# Altium schematic sheet Load_Share_controller.SchDoc
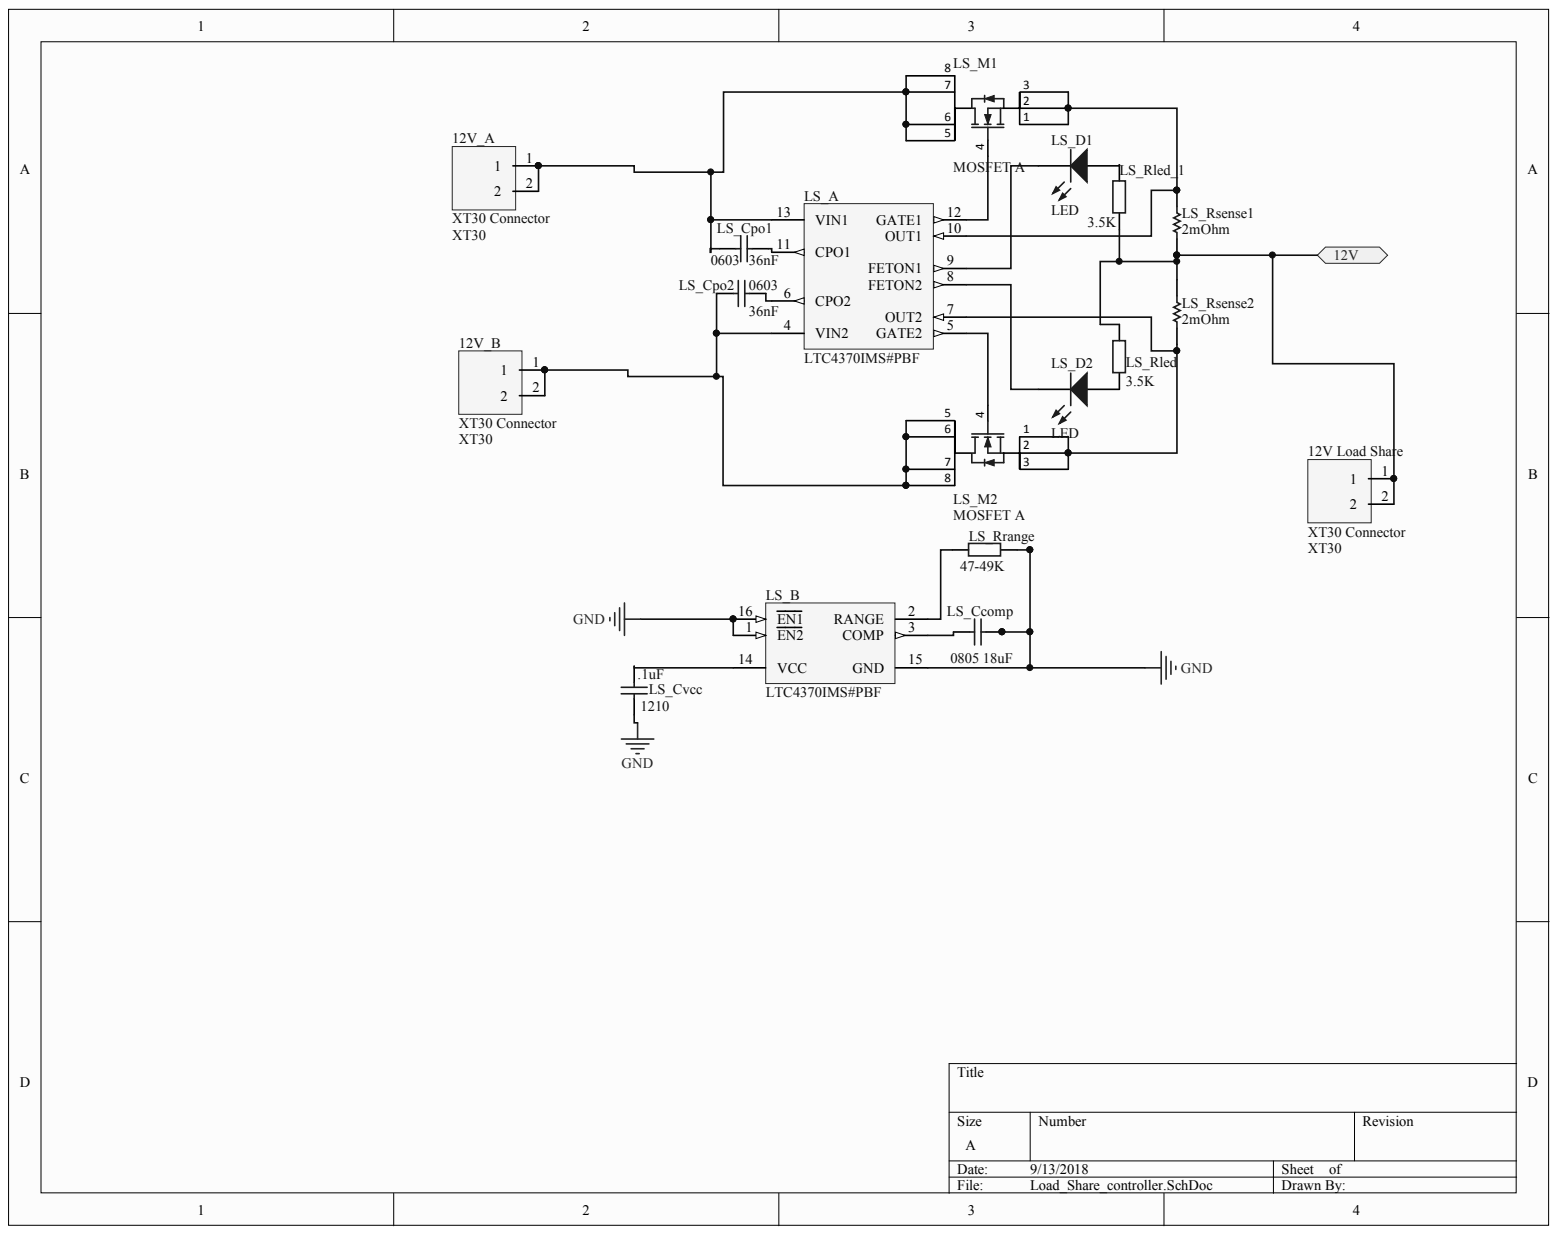
[diagram p0: Load_Share_controller.SchDoc]

## Parts
12V_Load_Share  XT30 Connector  Name: XT30  [PCB-k7b7qcz15wuei3yaobu8-1]
12V_A  XT30 Connector  Name: XT30  [PCB-k7b7qcz15wuei3yaobu8-1]
12V_B  XT30 Connector  Name: XT30  [PCB-k7b7qcz15wuei3yaobu8-1]
LS_  LTC4370IMS#PBF  [LT-MS-16_L]  (parts A,B)
LS_Ccomp  Capacitance: 18uF  Package: 0805  [CAPC2014X135X70LL20]  (hidden comment: AVX Interconnect / Elco TLCR107M004XTA)
LS_Cpo1  Capacitance: 36nF  Case/Package: 0603  [0603-CAP]  (hidden comment: Kemet C0603C561J5GACTU)
LS_Cpo2  Capacitance: 36nF  Case/Package: 0603  [0603-CAP]  (hidden comment: Kemet C0603C561J5GACTU)
LS_Cvcc  Capacitance: .1uF  Package: 1210  [PCB-xq3c4pfyq04n43f4i2bn-1]  (hidden comment: Taiyo Yuden HMK325B7225KN-T)
LS_D1  LED  [PCB-0nqcqa48p5nqkflv7v2c-1]
LS_D2  LED  [PCB-0nqcqa48p5nqkflv7v2c-1]
LS_M1  MOSFET A  [PCB-19722066-1]
LS_M2  MOSFET A  [PCB-19722066-1]
LS_Rled  Resistance: 3.5K  [PCB-xt88hm5qtlxo4k7muxaf-1]
LS_Rled_1  Resistance: 3.5K  [PCB-xt88hm5qtlxo4k7muxaf-1]
LS_Rrange  Resistance: 47-49K  [PCB-xt88hm5qtlxo4k7muxaf-1]
LS_Rsense1  2mOhm  [PCB-9l1y7q8wfh2j5q7sti4n-1]
LS_Rsense2  2mOhm  [PCB-9l1y7q8wfh2j5q7sti4n-1]

## Ports
12V

## Nets
GND: LS_.1(EN2) LS_.15(GND) LS_.16(EN1) LS_Ccomp.2 LS_Cvcc.1 LS_Rrange.1
12V: 12V_Load_Share.1 12V_Load_Share.2 LS_Rled.2 LS_Rled_1.1 LS_Rsense1.1 LS_Rsense2.2 ; port 12V
Net12V_A_1: 12V_A.1 12V_A.2 LS_.13(VIN1) LS_Cpo1.1 LS_M1.5 LS_M1.6 LS_M1.7 LS_M1.8
Net12V_B_1: 12V_B.1 12V_B.2 LS_.4(VIN2) LS_Cpo2.1 LS_M2.5 LS_M2.6 LS_M2.7 LS_M2.8
NetLS__2: LS_.2(RANGE) LS_Rrange.2
NetLS__3: LS_.3(COMP) LS_Ccomp.1
NetLS__5: LS_.5(GATE2) LS_M2.4
NetLS__6: LS_.6(CPO2) LS_Cpo2.2
NetLS__7: LS_.7(OUT2) LS_M2.1 LS_M2.2 LS_M2.3 LS_Rsense2.1
NetLS__8: LS_.8(FETON2) LS_D2.2
NetLS__9: LS_.9(FETON1) LS_D1.2
NetLS__10: LS_.10(OUT1) LS_M1.1 LS_M1.2 LS_M1.3 LS_Rsense1.2
NetLS__11: LS_.11(CPO1) LS_Cpo1.2
NetLS__12: LS_.12(GATE1) LS_M1.4
NetLS__14: LS_.14(VCC) LS_Cvcc.2
NetLS_D1_1: LS_D1.1 LS_Rled_1.2
NetLS_D2_1: LS_D2.1 LS_Rled.1

## Library data of the parts
12V_A 12V_B 12V_Load_Share = CMP-nim89fczalak95wzdygw-1: Footprint for the XT30 connector (smaller version of the XT60); Component Kind: Standard; CustomComponentID: nim89fczalak95wzdygw; Library Name: FavoritesLibrary; Pins: 2
LS_ = CMP-1162515-2: Two-Supply Diode-OR Current Balancing Controller, 0 to 18 V Vin, 16-pin SOP (MS-16), -40 to 85 degC, Pb-Free; Lead-Free Status: Lead Free; Component Kind: Standard; Library Name: CommunityVault; Manufacturer Part Number: LTC4370IMS#PBF; Number of Pins: 16; OctopartID: bd5375054e696294; Octopart Url: https://octopart.com/ltc4370ims%23pbf-linear+technology-22861404; REACH SVHC Compliance: No SVHC; RoHS: Compliant
LS_Ccomp = CMP-224528-2: CAP TANT 100UF 4V 20% 0805; Case/Package (SI): 2012; Dielectric Material: Tantalum; Equivalent Series Resistance (ESR): 5.0 Ω; Lead-Free Status: Lead Free; Voltage Rating (DC): 4.0 V; Capacitance Tolerance: ±20%; Component Kind: Standard; Library Name: CommunityVault; Manufacturer Part Number: TLCR107M004XTA; Mounting Style: Surface Mount; Number of Pins: 2; OctopartID: 24c5edcc5c94fb02; Octopart Url: https://octopart.com/tlcr107m004xta-avx+interconnect+%2F+elco-220471; REACH SVHC Compliance: No SVHC; RoHS: Compliant; Size-Height: 0.00135 m; Size-Length: 0.0025 m; Size-Width: 0.00135 m
LS_Cpo1 LS_Cpo2 = CMP-123892-4: CAP CER 560PF 50V 5% NP0 0603; Dielectric Characteristic: C0G/NP0; Dielectric Material: Ceramic; Lead-Free Status: Lead Free; Voltage Rating (DC): 50.0 V; Capacitance Tolerance: ±5%; Component Kind: Standard; Library Name: CommunityVault; Manufacturer Part Number: C0603C561J5GACTU; Mounting Style: Surface Mount; Number of Pins: 2; OctopartID: 0aabc3a08ab6d56c; Octopart Url: https://octopart.com/c0603c561j5gactu-kemet-107899; Packaging: Cut Tape (CT); REACH SVHC Compliance: No SVHC; RoHS: Compliant; Size-Height: 0.0008 m; Size-Length: 0.0016 m; Size-Width: 0.0008 m
LS_Cvcc = CMP-050ecc6fcd80dac9-2: Cap Ceramic 2.2uF 100V X7R 10% SMD 1210 125°C Embossed T/R; Voltage Rating (DC): 100.0 V; Capacitance Tolerance: ±10%; Component Kind: Standard; Dielectric Characteristic: X7R; Library Name: CommunityVault; Lifecycle Status: Not Listed by Manufacturer; Manufacturer Part Number: HMK325B7225KN-T; Number of Pins: 2; OctopartID: 050ecc6fcd80dac9; Octopart URL: http://octopart.com/hmk325b7225kn-t-taiyo+yuden-7073256; Packaging: Cut Tape (CT); RoHS: Compliant
LS_D1 LS_D2 = CMP-39eef09c3dcce629-1: LED; Through Hole; 3 mm; UV; 395nm; Water Clear Lens; 30 Deg Viewing Angle; Flanged; Component Kind: Standard; Library Name: CommunityVault; RoHS: Compliant
LS_M1 LS_M2 = CMP-19722066-6: N-Ch MOSFET SO-8 BWL split gate 30V 3.4mohm @ 10V; Manufacturer Part Number: SI4010DY-T1-GE3; Component Kind: Standard; Library Name: CommunityVault; OctopartID: f47d3fa458162bba; Octopart Url: https://octopart.com/si4010dy-t1-ge3-vishay-47417613
LS_Rled LS_Rled_1 LS_Rrange = CMP-2cc3e5c9bb4df304-35: Res GENERIC THT RESISTOR; Manufacturer Part Number: GNRIC; Lead-Free Status: Lead Free; Lead Length: 38.0 mm; Component Kind: Standard; Library Name: CommunityVault; Mounting Style: Axial, Through Hole; Number of Pins: 2; OctopartID: 2cc3e5c9bb4df304; Size-Diameter: 7.00 mm; Size-Length: 22.6 mm
LS_Rsense1 LS_Rsense2 = CMP-5d4e961b39a48289-1: Res Thick Film 2512 0.003 Ohm 1% 1W 50ppm/Â°C to 150ppm/Â°C Molded SMD SMD Embossed Carrier T/R; Power Rating: 1.00 W; Component Kind: Standard; Library Name: CommunityVault; Lifecycle Status: Not Listed by Manufacturer; Mounting Style: Surface Mount; Octopart URL: http://octopart.com/erj-m1wtf3m0u-panasonic-39797132; Packaging: Tape & Reel (TR), Cut Tape (CT); Resistance Tolerance: ±1%; Size-Height: 800 µm; Size-Length: 6.40 mm; Size-Width: 3.20 mm
